# Altium schematic sheet IMD.SchDoc(IMD)
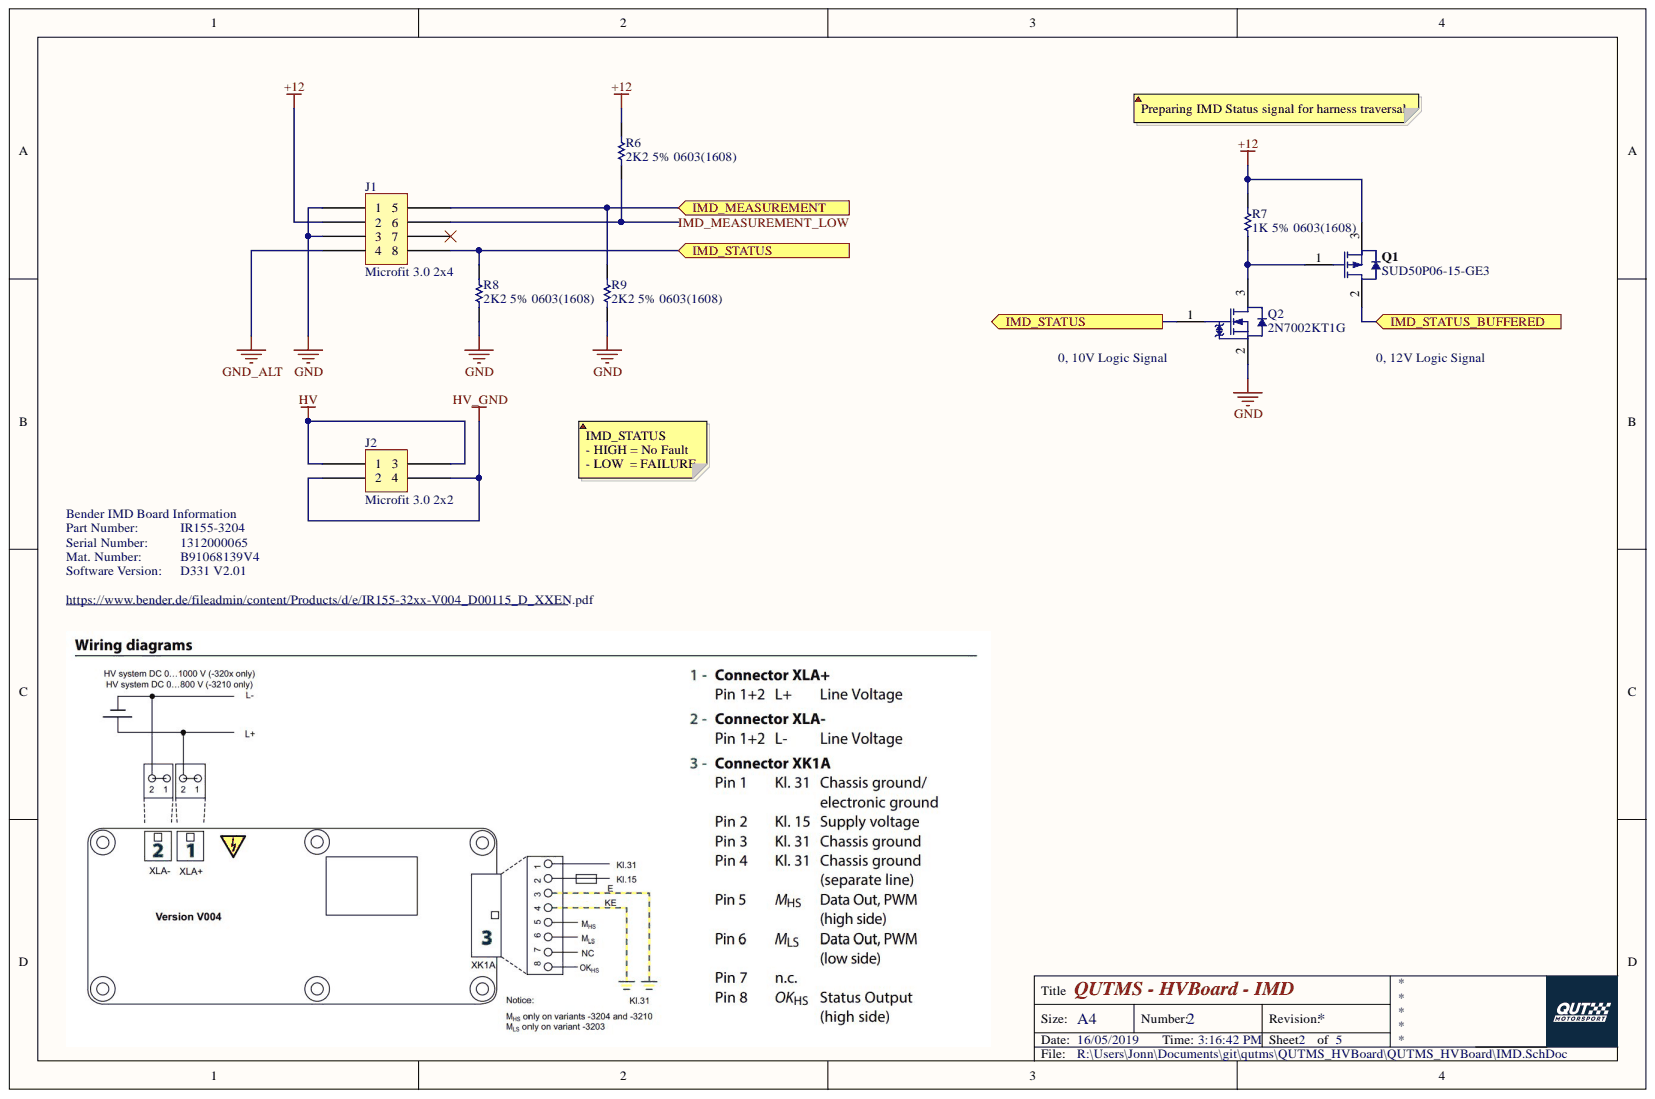
[diagram p0: IMD.SchDoc]

## Parts
J1  Microfit 3.0 2x4  [Microfit_2X4_R_TH]
J2  Microfit 3.0 2x2  [Microfit_2X2_R_TH]
Q1  SUD50P06-15-GE3  [VSIL-TO-252AA-3_V]
Q2  2N7002KT1G  [ONSC-SOT-23-3-318-08_V]
R6  2K2 5% 0603(1608)  [RESC0603(1608)_N]
R7  1K 5% 0603(1608)  [RESC0603(1608)_L]
R8  2K2 5% 0603(1608)  [RESC0603(1608)_N]
R9  2K2 5% 0603(1608)  [RESC0603(1608)_N]

## Ports
IMD_MEASUREMENT, IMD_STATUS, IMD_STATUS_BUFFERED

## Nets
+12: J1.2 Q1.3 R6.2 R7.2
GND: J1.1 J1.3 Q2.2 R8.1 R9.1
GND_ALT: J1.4
HV: J2.1 J2.3
HV_GND: J2.2 J2.4
IMD_MEASUREMENT: J1.5 R9.2 ; port IMD_MEASUREMENT
IMD_MEASUREMENT_LOW: J1.6 R6.1
IMD_STATUS: J1.8 Q2.1 R8.2 ; port IMD_STATUS
IMD_STATUS_BUFFERED: Q1.2 ; port IMD_STATUS_BUFFERED
NetQ1_1: Q1.1 Q2.3 R7.1

Not connected: J1 7

## Library data of the parts
J1 = Microfit_2x4: Component Kind: Standard; Library Name: QUTMS_Microfit.SchLib
J2 = Microfit_2x2: Component Kind: Standard; Library Name: QUTMS_Microfit.SchLib
Q1 = CMP-2000-05431-1: P-Channel (D-S) MOSFET, 30 V, -55 to 150 degC, 3-Pin TO-252, RoHS, Tape and Reel; Manufacturer: Vishay; Vgs - Gate-Source Voltage: 20V; Vds - Drain-Source Breakdown Voltage: -60V; Unit Weight: 0.050717oz; Typical Turn-On Delay Time: 15ns; Typical Turn-Off Delay Time: 175ns; Transistor Type: 1P-Channel; Rise Time: 70ns; Rds On - Drain-Source Resistance: 15mOhms; Pd - Power Dissipation: 2.5W; Number of Channels: 1Channel; Id - Continuous Drain Current: 50A; Configuration: Single; Channel Mode: Enhancement; Brand: VishaySemiconductors; Width: 6.22mm; Transistor Polarity: P-Channel; Tradename: TrenchFET; Technology: Si; Series: SUD; RoHS: True; Product Category: MOSFET; Packaging: Reel; Package URL: http://www.vishay.com/docs/89975/v15wl45c-m3.pdf; Package Reference: TO-252; Package Description: 3-Pin Surface Mount Device, Body 6.54 x 6.095 mm, Pitch 2.28 mm; Package / Case: TO-252AA-3; Mounting Style: SMD/SMT; Minimum Operating Temperature: -55C; Maximum Operating Temperature: +150C; Manufacturer URL: http://www.vishay.com/; Library Name: Altium Content Vault; Length: 6.73mm; Height: 2.39mm; Fall Time: 175ns; Factory Pack Quantity: 2000; Datasheet Version: Rev. C, 07/2013; Datasheet URL: https://datasheet.ciiva.com/4444/sud50p06-244530-4444327.pdf; Component Kind: Standard
Q2 = CMP-1058-00766-1: Small Signal MOSFET, 60 V, 380 mA, Single, N-Channel, 3-Pin SOT-23, Pb-Free, Tape and Reel; Manufacturer: On Semiconductor; VGS Max (V): 20; VGS(th) Max (V): 2.3; V(BR)DSS Min (V): 60; ID Max (A): 0.38; Crss Typ (pF): 2.2; Coss Typ (pF): 4.2; Ciss Typ (pF): 24.5; Channel Polarity: N Channel; RoHS: Pb-Free; PartNumber: 2N7002KT1G; Packing: Tape and Reel; PackageVersion: Rev. AP, 11/2009; Package Type: SOT-23-3; PackageReference: SOT-23-3-318-08; PackageDescription: 3-Pin Small Outline Transistor, Body 2.92 x 1.3 mm, Pitch 0.95 mm; Mounting Technology: Surface Mount; Library Name: Altium Content Vault; DatasheetVersion: Rev. 11; Configuration: Single; ComponentLink3URL: http://www.onsemi.cn/pub_link/Collateral/318-08.PDF; ComponentLink3Description: Package Specification; ComponentLink2URL: http://www.onsemi.com/pub_link/Collateral/2N7002K-D.PDF; ComponentLink2Description: Datasheet; ComponentLink1URL: http://www.onsemi.com/; ComponentLink1Description: Manufacturer URL; Component Kind: Standard
R6 R8 R9 = CMP-1012-00082-1: 2K2 0.1W 5% 0603 (1608 Metric)  SMD; Value: 2200.00 Ohm; Case-Metric: 1608; Case-EIA: 0603; Tolerance: 5 %; Technology: SMT; Power: 0.1 W; Library Name: Altium Content Vault; Component Kind: Standard
R7 = CMP-1012-00074-1: 1K 0.1W 5% 0603 (1608 Metric)  SMD; Value: 1000.00 Ohm; Case-Metric: 1608; Case-EIA: 0603; Tolerance: 5 %; Technology: SMT; Power: 0.1 W; Library Name: Altium Content Vault; Component Kind: Standard
